# Altium schematic sheet JacdacRgbLed1Ec30 77.SchDoc
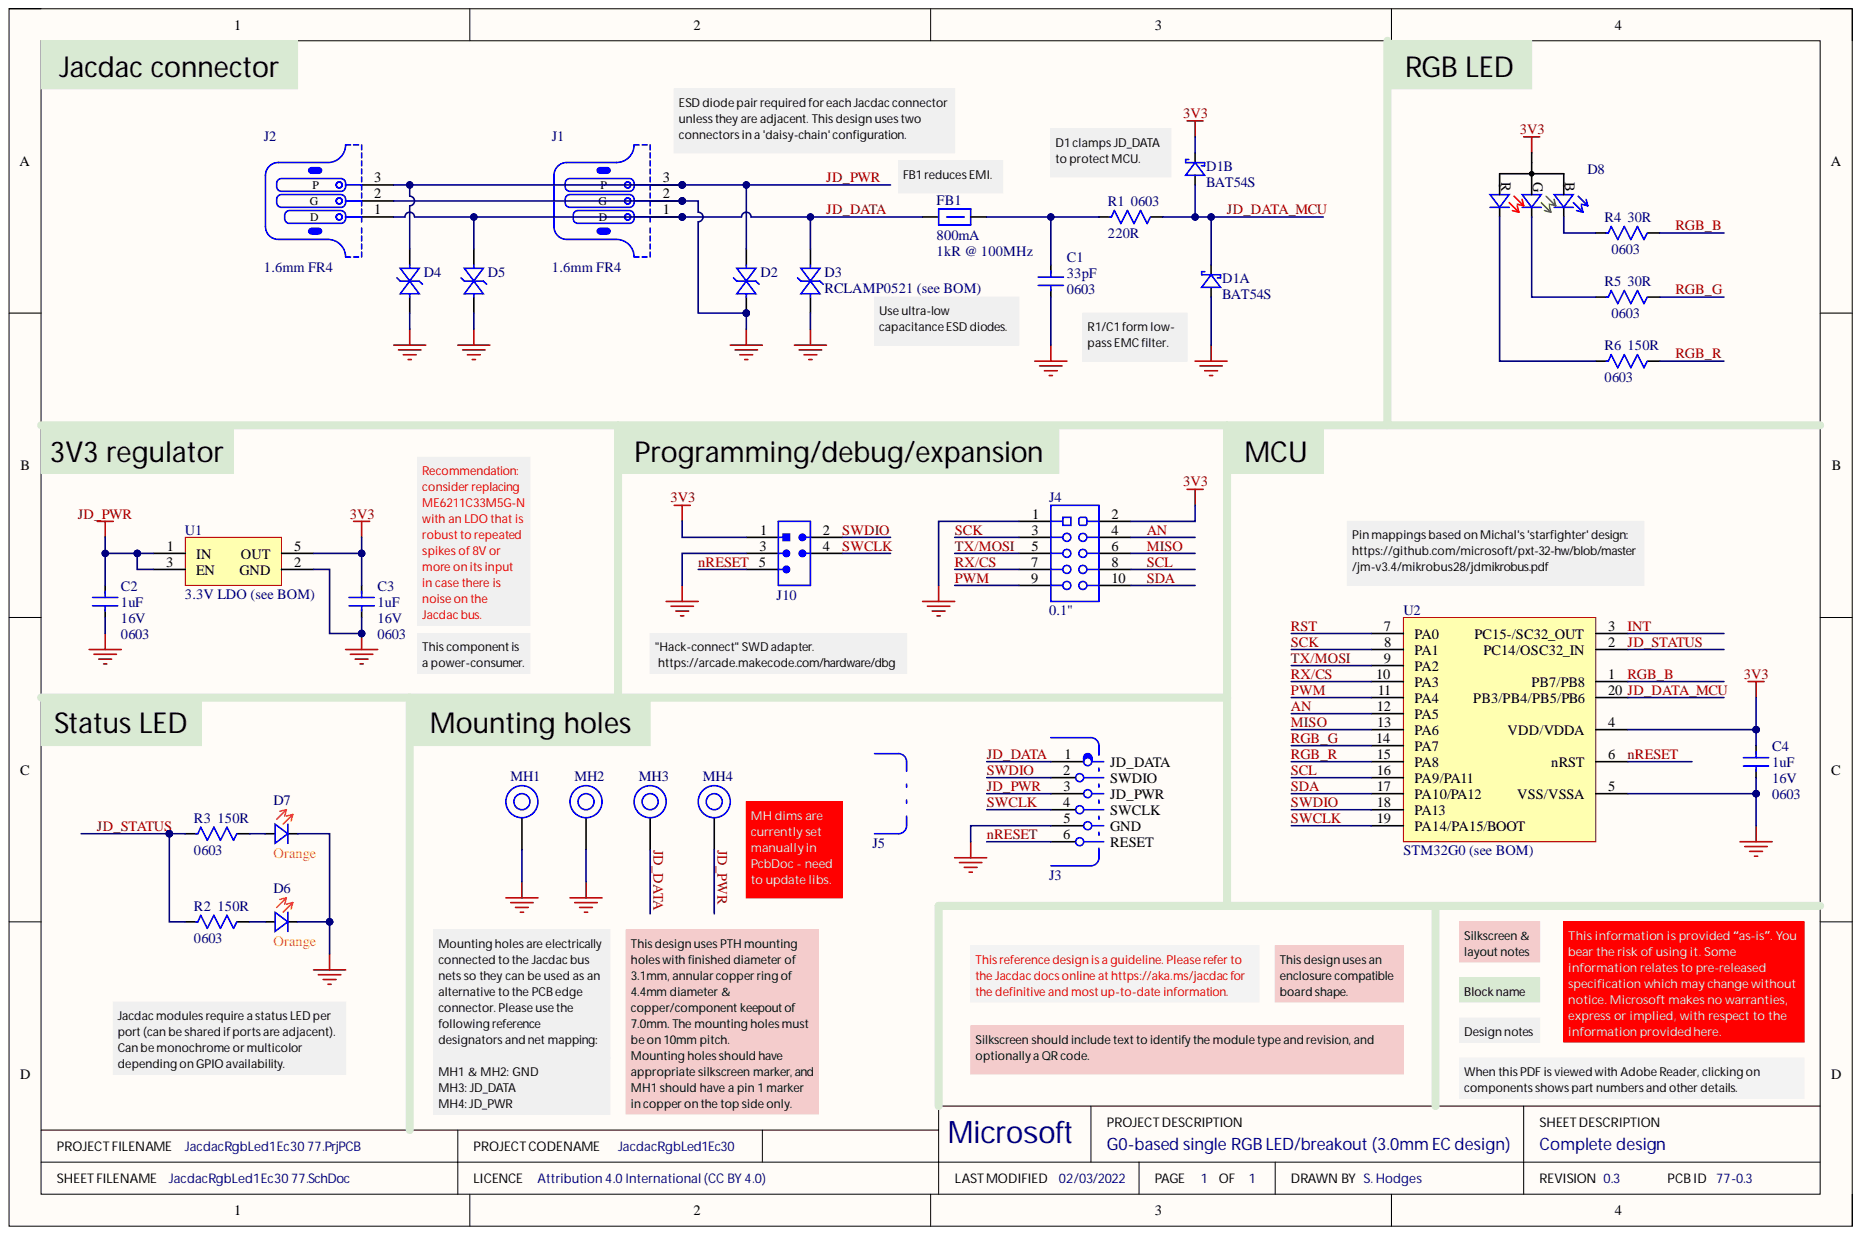
[diagram p0: JacdacRgbLed1Ec30 77.SchDoc]

## Parts
C1  Capacitance: 33pF  Case Code (Imperial): 0603
C2  Capacitance: 1uF  Case Code (Imperial): 0603  Voltage: 16V
C3  Capacitance: 1uF  Case Code (Imperial): 0603  Voltage: 16V
C4  Capacitance: 1uF  Case Code (Imperial): 0603  Voltage: 16V
D1  Manufacturer Part Number: BAT54S  (parts A,B)
D2  (no value)
D3  (no value)
D4  (no value)
D5  (no value)
D6  Color: Orange
D7  Color: Orange
D8  (no value)
FB1  Current Rating: 800mA
J1  PCB Type: 1.6mm FR4
J2  PCB Type: 1.6mm FR4
J3  (no value)
J4  (no value)
J5  (no value)
J10  (no value)
MH1  (no value)
MH2  (no value)
MH3  (no value)
MH4  (no value)
R1  Case Code (Imperial): 0603  Resistance: 220R
R2  Case Code (Imperial): 0603  Resistance: 150R
R3  Case Code (Imperial): 0603  Resistance: 150R
R4  Case Code (Imperial): 0603  Resistance: 30R
R5  Case Code (Imperial): 0603  Resistance: 30R
R6  Case Code (Imperial): 0603  Resistance: 150R
U1  Manufacturer Part Number 1: 3.3V LDO (see BOM)  Min Output Voltage: 3.3V
U2  Manufacturer Part Number: STM32G0 (see BOM)

## Nets
3V3: C3.2 C4.2 D1.2 D8.1 J4.2 J10.1 U1.5(OUT) U2.4(VDD/VDDA)
GND: C1.1 C2.1 C3.1 C4.1 D1.1 D2.2 D3.2 D4.2 D5.2 D6.1 D7.1 J1.2 J2.2 J3.5 J4.1 J10.3 MH1.1 MH2.1 U1.2(GND) U2.5(VSS/VSSA)
AN: J4.4 U2.12(PA5)
INT: U2.3(PC15-/SC32_OUT)
JD_DATA: D3.1 D5.1 FB1.1 J1.1 J2.1 J3.1 MH3.1
JD_DATA_MCU: D1.3 R1.2 U2.20(PB3/PB4/PB5/PB6)
JD_PWR: C2.2 D2.1 D4.1 J1.3 J2.3 J3.3 MH4.1 U1.1(IN) U1.3(EN)
JD_STATUS: R2.1 R3.1 U2.2(PC14/OSC32_IN)
MISO: J4.6 U2.13(PA6)
nRESET: J3.6 J10.5 U2.6(nRST)
PWM: J4.9 U2.11(PA4)
RGB_B: R4.2 U2.1(PB7/PB8)
RGB_G: R5.2 U2.14(PA7)
RGB_R: R6.2 U2.15(PA8)
RST: U2.7(PA0)
RX/CS: J4.7 U2.10(PA3)
SCK: J4.3 U2.8(PA1)
SCL: J4.8 U2.16(PA9/PA11)
SDA: J4.10 U2.17(PA10/PA12)
SWCLK: J3.4 J10.4 U2.19(PA14/PA15/BOOT)
SWDIO: J3.2 J10.2 U2.18(PA13)
TX/MOSI: J4.5 U2.9(PA2)
NetC1_2: C1.2 FB1.2
NetD6_2: D6.2 R2.2
NetD7_2: D7.2 R3.2
NetD8_2: D8.2(R) R6.1
NetD8_3: D8.3(G) R5.1
NetD8_4: D8.4 R4.1

Not connected: R1 1

## Library data of the parts
C1 = (no symbol name): Cap 33PF 50V 0603 SMD 5% NP0; Manufacturer 1: Yageo; Manufacturer Part Number 1: CC0603JRNPO9BN330; Dielectric: C0G; Dielectric Material: Ceramic; Insulation Resistance: 100GR; Temperature Coefficient: 30ppm/°C; Case Code (Metric): 1608; Case/Package: 0603; Category: Ceramic Capacitors; ComponentLink1Description: https://datasheet.ciiva.com/11786/0900766b8079c870-11786082.pdf?src-supplier=RSComponents; ComponentLink1URL: https://datasheet.ciiva.com/11786/0900766b8079c870-11786082.pdf?src-supplier=RSComponents; ComponentLink2Description: Online part number coding; ComponentLink2URL: https://datasheet.ciiva.com/144/mlccorderingcode-144075.pdf?src-supplier=Digi-Key; ComponentLink3Description: Online datasheet; ComponentLink3URL: https://datasheet.ciiva.com/17434/upy-gphc-x7r-6-3v-to-50v-8-17434263.pdf; ComponentLink4Description: Online datasheet; ComponentLink4URL: https://datasheet.ciiva.com/26829/getdatasheetpartid-403577-26829431.pdf; ComponentLink5Description: https://datasheet.ciiva.com/26862/getdatasheetpartid-403532-26862767.pdf?src-supplier=Verical; ComponentLink5URL: https://datasheet.ciiva.com/26862/getdatasheetpartid-403532-26862767.pdf?src-supplier=Verical; ComponentLink6Description: https://datasheet.ciiva.com/8033/1443161-8033735.pdf?src-supplier=Element14; ComponentLink6URL: https://datasheet.ciiva.com/8033/1443161-8033735.pdf?src-supplier=Element14; ComponentLink7Description: https://datasheet.ciiva.com/7978/1443161-7978142.pdf?src-supplier=Element14; ComponentLink7URL: https://datasheet.ciiva.com/7978/1443161-7978142.pdf?src-supplier=Element14; ComponentLink8Description: https://datasheet.ciiva.com/46430/1835847-46430155.pdf?src-supplier=Element14; ComponentLink8URL: https://datasheet.ciiva.com/46430/1835847-46430155.pdf?src-supplier=Element14; ComponentLink9Description: https://datasheet.ciiva.com/144/mlccorderingcode-144644.pdf?src-supplier=Digi-Key; ComponentLink9URL: https://datasheet.ciiva.com/144/mlccorderingcode-144644.pdf?src-supplier=Digi-Key; ComponentLink10Description: https://datasheet.ciiva.com/144/mlccorderingcode-144643.pdf?src-supplier=Digi-Key; ComponentLink10URL: https://datasheet.ciiva.com/144/mlccorderingcode-144643.pdf?src-supplier=Digi-Key; ComponentLink11Description: https://datasheet.ciiva.com/144/mlccorderingcode-144645.pdf?src-supplier=Digi-Key; ComponentLink11URL: https://datasheet.ciiva.com/144/mlccorderingcode-144645.pdf?src-supplier=Digi-Key; ComponentLink12Description: https://datasheet.ciiva.com/5426/upy-gp-np0-16v-to-50v-8-5426614.pdf?src-supplier=Mouser; ComponentLink12URL: https://datasheet.ciiva.com/5426/upy-gp-np0-16v-to-50v-8-5426614.pdf?src-supplier=Mouser; ComponentLink13Description: https://datasheet.ciiva.com/8033/1372269-8033734.pdf?src-supplier=Element14; ComponentLink13URL: https://datasheet.ciiva.com/8033/1372269-8033734.pdf?src-supplier=Element14; ComponentLink14Description: https://datasheet.ciiva.com/11464/upy-gp-np0-16v-to-50v-8-11464730.pdf?src-supplier=Avnet; ComponentLink14URL: https://datasheet.ciiva.com/11464/upy-gp-np0-16v-to-50v-8-11464730.pdf?src-supplier=Avnet; Max Operating Temperature: 125°C; Min Operating Temperature: -55°C; Number of Pins: 2; Resistor Type: Ceramic; RoHS Compliant: Yes; Series: CC; Supplier 1: Digi-Key; Supplier 2: Farnell; Supplier 3: RSComponents; Supplier 4: Mouser; Supplier 5: LCSC; Supplier Part Number 1: 311-1064-2-ND; Supplier Part Number 2: 2408499; Supplier Part Number 3: 2006492; Supplier Part Number 4: 603-C0603JRNPO9BN330; Supplier Part Number 5: C107047; Termination: SMD/SMT; Tolerance: 5%; Voltage: 50V; Voltage Rating: 50V; Voltage Rating (DC): 50V
C2-C4 = (no symbol name): SMD Multilayer Ceramic Capacitor, 1 µF, 16 V, 0603 [1608 Metric], ± 10%, X5R, CL Series; Manufacturer 1: Samsung; Manufacturer Part Number 1: CL10A105KO8NNNC; Case Code (Metric): 1608; Case/Package: 0603; Category: Ceramic Capacitors; ComponentLink1Description: Online datasheet; ComponentLink1URL: https://datasheet.lcsc.com/lcsc/1811021622_Samsung-Electro-Mechanics-CL10A105KO8NNNC_C1592.pdfq; Construction: Flat; Contact Plating: Tin; Dielectric: X5R; Material: Ceramic; Max Operating Temperature: 85°C; Min Operating Temperature: -55°C; RoHS Compliant: Yes; Series: CL; Supplier 1: Digi-Key; Supplier 2: Farnell; Supplier 3: RSComponents; Supplier 4: Mouser; Supplier 5: LCSC; Supplier Part Number 1: 1276-1034-1-ND; Supplier Part Number 2: 3013384; Supplier Part Number 3: 7664780; Supplier Part Number 4: 187-CL10A105KO8NNNC; Supplier Part Number 5: C1592; Tolerance: 10%; Voltage Rating: 16V; Voltage Rating (DC): 16V
D1 = (no symbol name): DIODE ARRAY SCHOTTKY 30V SOT23; Manufacturer: Nexperia; Category: Rectifier Diodes; ComponentLink1Description: NXP datasheet online; ComponentLink1URL: https://assets.nexperia.com/documents/data-sheet/BAT54_SER.pdf; Supplier 1: Digi-Key; Supplier 2: Mouser; Supplier 3: RSComponents; Supplier Part Number 1: 1727-1868-1-ND; Supplier Part Number 2: 771-BAT54S235; Supplier Part Number 3: 1707990
D2 D4 D5 = (no symbol name): Bidirectional 5V (Max) 6V 16V 3.5A (8/20us) 0402 TVS RoHS; Manufacturer 1: Born Semiconductor; Manufacturer Part Number 1: RCLAMP0521P-N; ComponentLink1Description: Online datasheet; ComponentLink1URL: https://datasheet.lcsc.com/szlcsc/Bourne-Semicon-Shenzhen-RCLAMP0521P-N_C316043.pdf; Package: 0402; Supplier 1: LCSC; Supplier Part Number 1: C316043
D3 = (no symbol name): Bidirectional 5V (Max) 6V 16V 3.5A (8/20us) 0402 TVS RoHS; Manufacturer 1: Born Semiconductor; Manufacturer Part Number 1: RCLAMP0521 (see BOM); ComponentLink1Description: Online datasheet; ComponentLink1URL: https://datasheet.lcsc.com/szlcsc/Bourne-Semicon-Shenzhen-RCLAMP0521P-N_C316043.pdf; Package: 0402; Supplier 1: LCSC; Supplier Part Number 1: C316043
D6 D7 = (no symbol name): LED ORANGE DIFFUSED 0603 SMD; Manufacturer 1: Everlight Elec; Manufacturer Part Number 1: 19-217/S2C-AQ1R1B/3T; Case Code (Imperial): 0603; Case/Package: 0603; Colour: Orange; ComponentLink1Description: Online datasheet; ComponentLink1URL: https://datasheet.lcsc.com/lcsc/1912171731_Everlight-Elec-19-217-S2C-AQ1R1B-3T_C146215.pdf; Supplier 1: LCSC; Supplier Part Number 1: C146215
D8 = (no symbol name): 1615 Light Emitting Diodes (LED) RoHS; Manufacturer 1: TUOZHAN; Manufacturer Part Number 1: TZ-P4-1615RGBTCA1-0.55T; Category: Optoelectronics; ComponentLink1Description: Online datasheet; ComponentLink1URL: https://datasheet.lcsc.com/szlcsc/2009041238_TUOZHAN-TZ-P4-1615RGBTCA1-0-55T_C779813.pdf; Package: 1615; Size / Dimension: 1.6x1.5mm; Supplier 1: LCSC; Supplier Part Number 1: C779813
FB1 = (no symbol name): 0603 1k@100MHz 0.8A chip; Manufacturer: TDK; Manufacturer Part Number: MPZ1608S102ATA00; Core Material: Ferrite; DC Resistance (DCR): 300mR; Impedance: 1kR @ 100MHz; Tolerance: 25%; Case Code (Imperial): 0603; Case Code (Metric): 1608; Case/Package: 0603; ComponentLink2Description: datasheet online; ComponentLink2URL: https://www.mouser.co.uk/datasheet/2/400/eads_commercial_power_mpz1608_en-923650.pdf; ComponentLink3Description: datasheet; Max DC Current: 800mA; Supplier 1: Digi-Key; Supplier 2: Mouser; Supplier Part Number 1: 445-8694-1-ND; Supplier Part Number 2: 810-MPZ1608S102ATA00; ComponentLink3URL: Y:\System\Altium\Libraries 2014\TDK\Datasheets\eads_commercial_power_mpz1608_en-923650.pdf
J1 J2 = (no symbol name): 3 pin Jacdac edge connector 1.27mm pitch; Manufacturer Part Number: JACDAC_EDGE_CONNECTOR; ComponentLink1Description: Yuliang JACDAC cable connector drawing online; ComponentLink1URL: https://github.com/microsoft/jacdac-mdk/blob/main/connector/JACDAC-Connector-by-Yuliang-2020-11-28.pdf; ComponentLink2Description: JACDAC PCB edge connector spec onlin; ComponentLink2URL: https://github.com/microsoft/jacdac-mdk/blob/main/connector/JACDAC_PCB_Edge_Connector_Drawing_JD-PEC-01_rev_01.pdf
J3 = (no symbol name): 3x2 way 'mouse bite' connector for Jacdac and SWD
J4 = (no symbol name): 2.54mm, 5x2 way, vertical, square pin, gold plate; Manufacturer: Multicomp; Manufacturer Part Number: 2213S-10G; Gender: Male; Insulation Resistance: 1GR; Number of Contacts: 10; ComponentLink1Description: mulitcomp datasheet; ComponentLink1URL: http://www.farnell.com/datasheets/1697530.pdf; Contact Material: Copper Alloy; Contact Plating: Gold; Flammability Rating: UL94 V-0; Number of Rows: 2; Supplier 1: Farnell; Supplier Part Number 1: 1593442; Termination: Through Hole; Weight: 0.11lb
J5 = (no symbol name): 3 way 'mouse bite' connector
J10 = (no symbol name): Hack Connect Mini 1.27 mm pitch 2-row
MH1-MH4 = (no symbol name): Mounting Hole 6.5mm keepout, 4.5mm annualar ring and 3.0mm Drill PTH
R1 = (no symbol name): Res Thick Film 0603 220 Ohm 1% 0.1W(1/10W) ±100ppm/°C Pad SMD T/R; Manufacturer 1: Yageo; Manufacturer Part Number 1: RC0603FR-07220RL; Max Power Dissipation: 100mW; Voltage Rating: 50V; Case Code (Metric): 1608; Case/Package: 0603; Category: Chip SMD Resistors; ComponentLink1Description: Online datasheet; ComponentLink1URL: https://datasheet.ciiva.com/11819/0900766b80f96399-11819290.pdf; Construction: Rectangular; Features: Moisture Resistant; Max Operating Temperature: 155°C; Min Operating Temperature: -55°C; Number of Pins: 2; Number of Terminations: 2; Power Rating: 100mW; Resistance Tolerance: 1%; Resistor Type: Thick Film; RoHS Compliant: Yes; Series: RC; Size Code: 0603; Supplier 1: Digi-Key; Supplier 2: Farnell; Supplier 3: RSComponents; Supplier 4: Mouser; Supplier 5: LCSC; Supplier Part Number 1: 311-220HRCT-ND; Supplier Part Number 2: 9238409; Supplier Part Number 3: 1995497; Supplier Part Number 4: 603-RC0603FR-07220RL; Supplier Part Number 5: C107696; Temperature Coefficient: 100ppm/°C; Termination: SMD/SMT; Tolerance: 1%; Voltage Rating (DC): 50V
R2 R3 R6 = (no symbol name): Res General Purpose Thick Film 0603 150 Ohm 1% 1/10W ±100ppm/°C Molded SMD Paper T/R; Manufacturer 1: Yageo; Manufacturer Part Number 1: RC0603FR-07150RL; Max Power Dissipation: 100mW; Voltage Rating: 50V; Case Code (Metric): 1608; Case/Package: 0603; Category: Chip SMD Resistors; ComponentLink1Description: Online datasheet; ComponentLink1URL: https://datasheet.ciiva.com/11819/0900766b80f96399-11819290.pdf; Construction: Rectangular; Features: Moisture Resistant; Max Operating Temperature: 155°C; Min Operating Temperature: -55°C; Number of Pins: 2; Number of Terminations: 2; Power Rating: 100mW; Resistance Tolerance: 1%; Resistor Type: Thick Film; RoHS Compliant: Yes; Series: RC; Size Code: 0603; Supplier 1: Digi-Key; Supplier 2: Farnell; Supplier 3: RSComponents; Supplier 4: Mouser; Supplier 5: LCSC; Supplier Part Number 1: 311-150HRCT-ND; Supplier Part Number 2: 9238387; Supplier Part Number 3: 1995464; Supplier Part Number 4: 603-RC0603FR-07150RL; Supplier Part Number 5: C114608; Temperature Coefficient: 100ppm/°C; Termination: SMD/SMT; Tolerance: 1%; Voltage Rating (DC): 50V
R4 R5 = (no symbol name): Res Thick Film 0603 30 Ohm 1% 0.1W(1/10W) ±100ppm/C Pad SMD T/R; Manufacturer 1: Yageo; Manufacturer Part Number 1: RC0603FR-0730RL; Max Power Dissipation: 100mW; Temperature Coefficient: 100ppm/°C; Voltage Rating: 50V; Case Code (Metric): 1608; Case/Package: 0603; Category: Chip SMD Resistors; ComponentLink1Description: Online datasheet; ComponentLink1URL: https://datasheet.ciiva.com/11819/0900766b80f96399-11819290.pdf; ComponentLink2Description: https://datasheet.ciiva.com/11819/0900766b80c66297-11819676.pdf?src-supplier=RSComponents; ComponentLink2URL: https://datasheet.ciiva.com/11819/0900766b80c66297-11819676.pdf?src-supplier=RSComponents; ComponentLink3Description: https://datasheet.ciiva.com/2455/pyu-r-int-thick-7-2455119.pdf?src-supplier=Digi-Key; ComponentLink3URL: https://datasheet.ciiva.com/2455/pyu-r-int-thick-7-2455119.pdf?src-supplier=Digi-Key; ComponentLink4Description: https://datasheet.ciiva.com/2455/pyu-r-int-thick-7-2455120.pdf?src-supplier=Digi-Key; ComponentLink4URL: https://datasheet.ciiva.com/2455/pyu-r-int-thick-7-2455120.pdf?src-supplier=Digi-Key; ComponentLink5Description: https://datasheet.ciiva.com/2455/pyu-r-int-thick-7-2455121.pdf?src-supplier=Digi-Key; ComponentLink5URL: https://datasheet.ciiva.com/2455/pyu-r-int-thick-7-2455121.pdf?src-supplier=Digi-Key; Construction: Rectangular; Features: Moisture Resistant; Max Operating Temperature: 155°C; Min Operating Temperature: -55°C; Number of Terminations: 2; Power Rating: 100mW; Resistor Type: Thick Film; RoHS Compliant: Yes; Series: RC; Size Code: 0603; Supplier 1: Digi-Key; Supplier 2: Farnell; Supplier 3: Mouser; Supplier 5: LCSC; Supplier Part Number 1: 311-30.0HRCT-ND; Supplier Part Number 2: 3495813; Supplier Part Number 3: 603-RC0603FR-0730RL; Supplier Part Number 5: C128060; Technology: THICK FILM; Termination: SMD/SMT; Tolerance: 1%; Voltage Rating (DC): 50V
U1 = (no symbol name): 6V 260mV @ 200mA(typ) - - Fixed 3.3V SOT-23-5 Linear Voltage Regulators (LDO) ROHS; Manufacturer 1: MICRONE(Nanjing Micro One Elec); Dropout Voltage: 200mV; Max Input Voltage: 6; Number of Regulators: 1; Output Configuration: Positive; Output Current: 500mA; Case/Package: SOT-23-5; Category: Voltage Regulators - Linear; ComponentLink3Description: On Semi datasheet online; ComponentLink3URL: https://datasheet.lcsc.com/lcsc/1811131510_MICRONE-Nanjing-Micro-One-Elec-ME6211C33M5G-N_C82942.pdf; Output Type: Fixed; Supplier 1: LCSC; Supplier Part Number 1: C82942
U2 = (no symbol name): MCU 32-Bit STM32G031 ARM Cortex-M0+ RISC 32KB Flash 1.7V to 3.6V 20-Pin TSSOP Tube; Manufacturer: STMicroelectronics; Category: Microcontrollers; ComponentLink1Description: Online datasheet; ComponentLink1URL: https://www.st.com/resource/en/datasheet/stm32g031c6.pdf; Supplier 1: Digi-Key; Supplier 2: Farnell; Supplier 3: RSComponents; Supplier 4: LCSC; Supplier Part Number 1: 497-19774-ND; Supplier Part Number 2: 3246918; Supplier Part Number 3: 1939834; Supplier Part Number 4: C529333
